# Altium schematic sheet main_power_circuit_continue.SchDoc(main_power_circuit_continue)
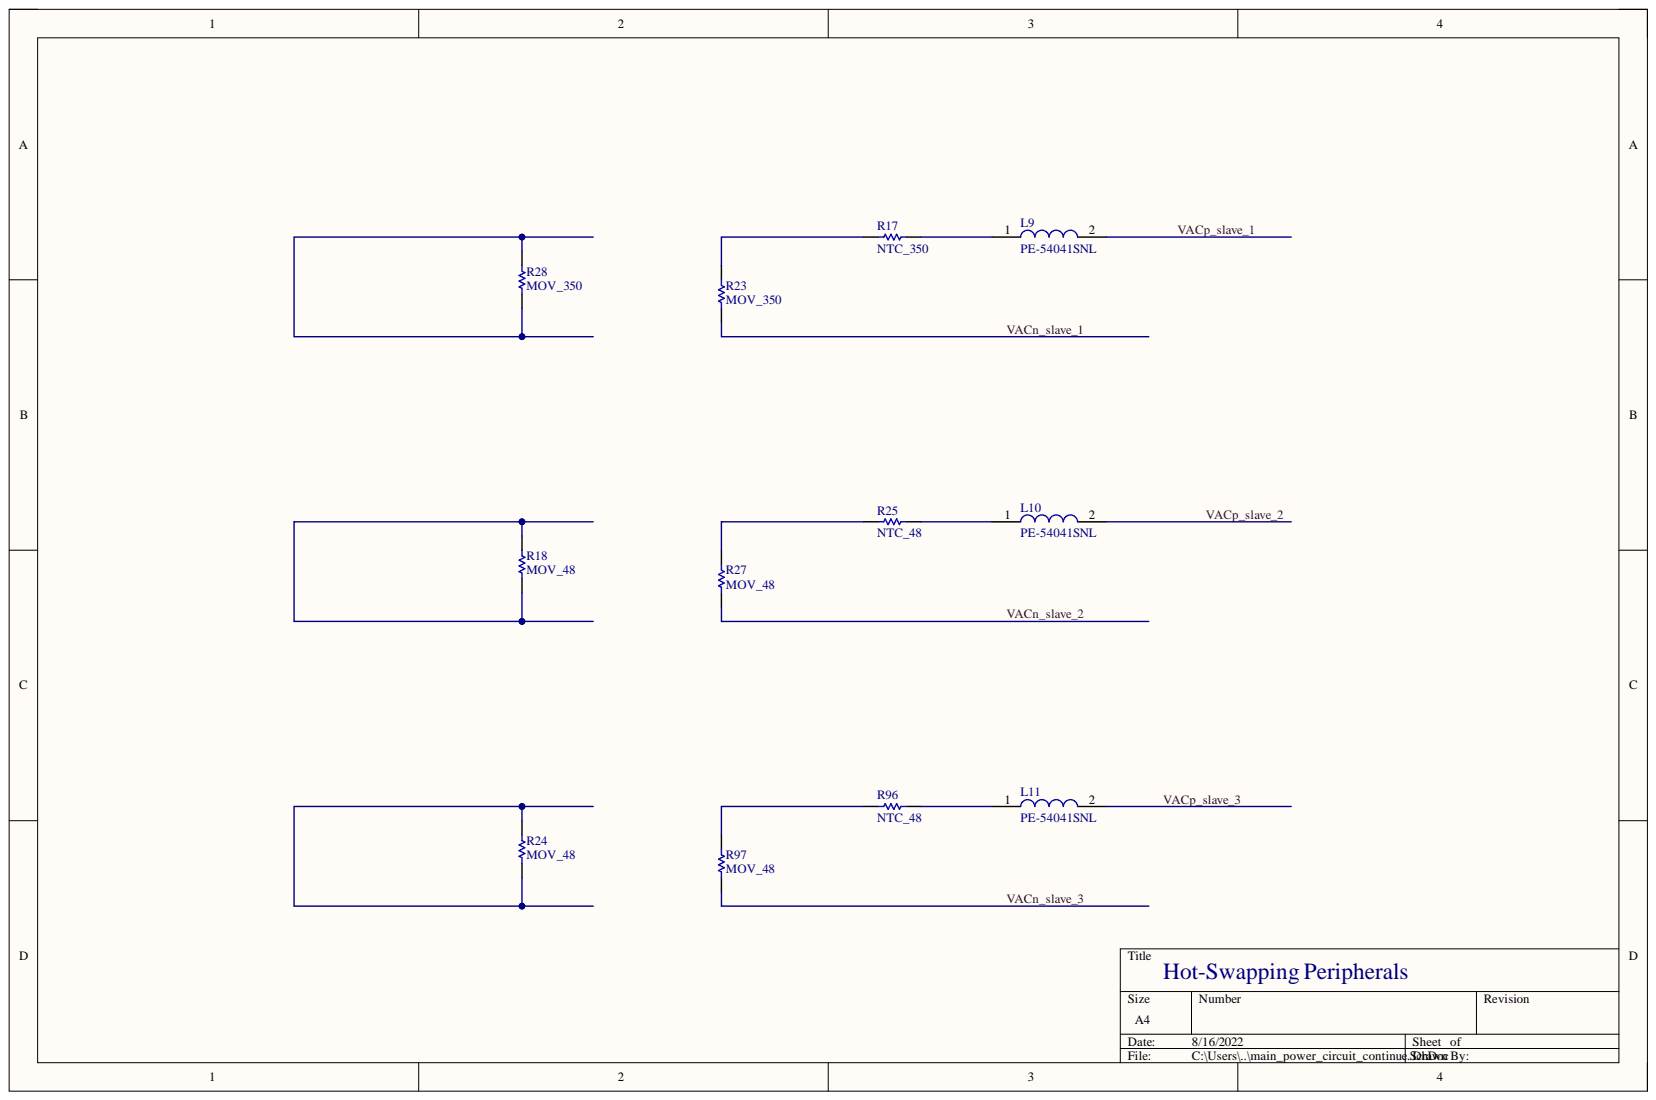
[diagram p0: main_power_circuit_continue.SchDoc]

## Parts
L9  PE-54041SNL  [P0846SNLT]
L10  PE-54041SNL  [P0846SNLT]
L11  PE-54041SNL  [P0846SNLT]
R17  NTC_350  [NTC_350]
R18  MOV_48  [MOV_350]
R23  MOV_350  [MOV_350]
R24  MOV_48  [MOV_350]
R25  NTC_48  [NTC_350]
R27  MOV_48  [MOV_350]
R28  MOV_350  [MOV_350]
R96  NTC_48  [NTC_350]
R97  MOV_48  [MOV_350]

## Nets
VACn_slave_1: R23.1
VACn_slave_2: R27.1
VACn_slave_3: R97.1
VACp_slave_1: L9.2
VACp_slave_2: L10.2
VACp_slave_3: L11.2
NetL9_1: L9.1 R17.2
NetL10_1: L10.1 R25.2
NetL11_1: L11.1 R96.2
NetR17_1: R17.1 R23.2
NetR18_1: R18.1 R18.2
NetR24_1: R24.1 R24.2
NetR25_1: R25.1 R27.2
NetR28_1: R28.1 R28.2
NetR96_1: R96.1 R97.2

## Library data of the parts
L9-L11 = PE-54041SNL: Inductor; Manufacturer_Name: Pulse; Component Kind: Standard; Datasheet Link: http://productfinder.pulseeng.com/products/datasheets/SPM2007_47.pdf; Height: 9mm; Manufacturer_Part_Number: PE-54041SNL
R17 R18 R23-R25 R27 R28 R96 R97 = Res1: Resistor; Value: 1K; Component Kind: Standard; LatestRevisionDate: 17-Jul-2002; LatestRevisionNote: Re-released for DXP Platform.; Library Name: Miscellaneous Devices.IntLib; PackageDescription: Axial Device, Thru-Hole; 2 Leads; 0.3 in Pin Spacing; PackageReference: AXIAL-0.3; Published: 8-Jun-2000; Signal Integrity: Res; Simulation: RESISTOR; Publisher: Altium Limited
